# Altium schematic sheet Relay.SchDoc
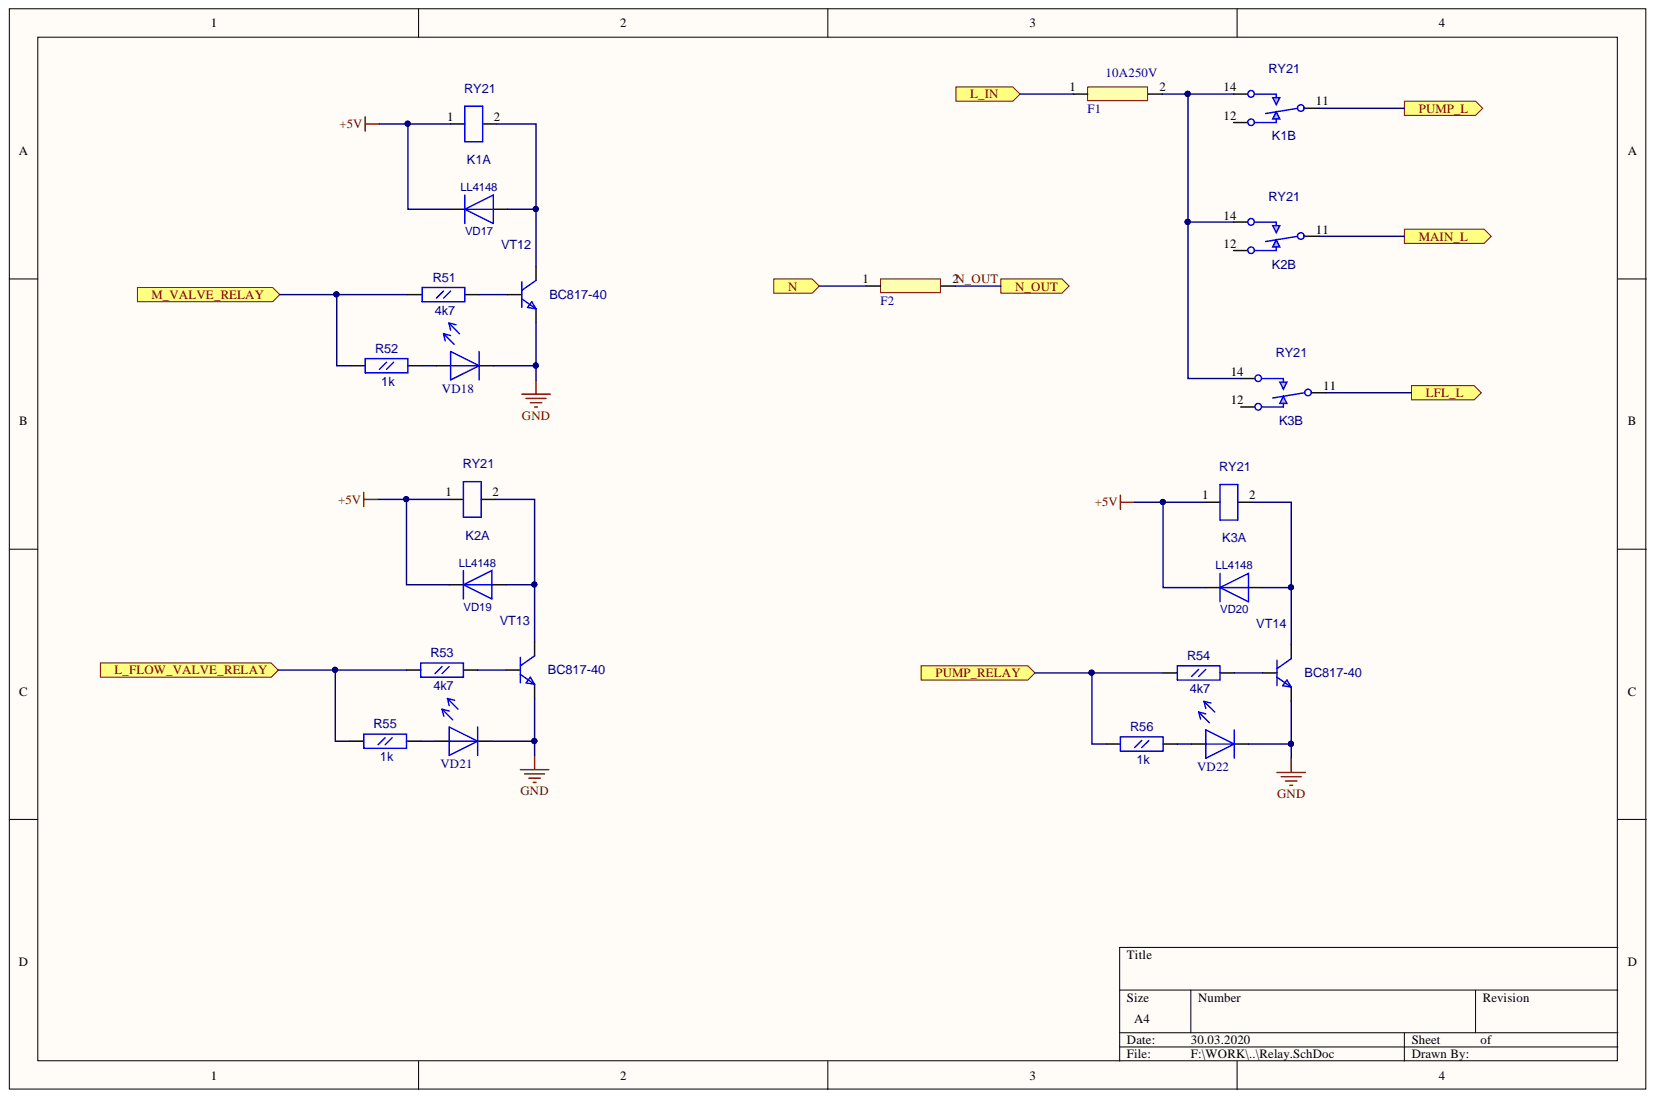
[diagram p0: Relay.SchDoc]

## Parts
F1  KLS5-266(5x20) 2pcs  [Fuse_5x20]  (value not drawn)
F2  KLS5-266(5x20) 2pcs  [Fuse_5x20]  (value not drawn)
K1  RY21  [RY21_RY21(Primary)]  (parts A,B)
K2  RY21  [RY21_RY21(Primary)]  (parts A,B)
K3  RY21  [RY21_RY21(Primary)]  (parts A,B)
R51  4k7  [RC0603]
R52  1k  [RC0603]
R53  4k7  [RC0603]
R54  4k7  [RC0603]
R55  1k  [RC0603]
R56  1k  [RC0603]
VD17  LL4148  [SOD-80]
VD18  LED_0805  [LED0805]  (value not drawn)
VD19  LL4148  [SOD-80]
VD20  LL4148  [SOD-80]
VD21  LED_0805  [LED0805]  (value not drawn)
VD22  LED_0805  [LED0805]  (value not drawn)
VT12  BC817-40  [SOT-23(123)]
VT13  BC817-40  [SOT-23(123)]
VT14  BC817-40  [SOT-23(123)]

## Ports
L_FLOW_VALVE_RELAY, L_IN, LFL_L, M_VALVE_RELAY, MAIN_L, N, N_OUT, PUMP_L, PUMP_RELAY

## Nets
+5V: K1.1 K2.1 K3.1 VD17.2 VD19.2 VD20.2
GND: VD18.2 VD21.2 VD22.2 VT12.2 VT13.2 VT14.2
L_FLOW_VALVE_RELAY: R53.1 R55.1 ; port L_FLOW_VALVE_RELAY
L_IN: F1.1 ; port L_IN
LFL_L: K3.11 ; port LFL_L
M_VALVE_RELAY: R51.1 R52.1 ; port M_VALVE_RELAY
MAIN_L: K2.11 ; port MAIN_L
N: F2.1 ; port N
N_OUT: F2.2 ; port N_OUT
PUMP_L: K1.11 ; port PUMP_L
PUMP_RELAY: R54.1 R56.1 ; port PUMP_RELAY
NetF1_2: F1.2 K1.14 K2.14 K3.14
NetK1_2: K1.2 VD17.1 VT12.3
NetK2_2: K2.2 VD19.1 VT13.3
NetK3_2: K3.2 VD20.1 VT14.3
NetR51_2: R51.2 VT12.1
NetR52_2: R52.2 VD18.1
NetR53_2: R53.2 VT13.1
NetR54_2: R54.2 VT14.1
NetR55_2: R55.2 VD21.1
NetR56_2: R56.2 VD22.1

Not connected: K1 12; K2 12; K3 12

## Library data of the parts
F1 F2 = Fuse KLS5-266(5x20): Component Kind: Standard; Value: KLS5-266(5x20) 2pcs; Library Name: gprs_modem_2.SchLib
R51-R56 = RC0603: Component Kind: Standard; Description: RC0603; Library Name: gprs_modem_2.SchLib
  R51 R53 R54: Value: 4k7
  R52 R55 R56: Value: 1k
VT12-VT14 = BC846BLT1: NPN 500ma transistor; ComponentField7: Published 29-Sep-2000; Component Kind: Standard; OriginalName: BC846BLT1; Simulation: BC846BLT1; Value: BC817-40; Library Name: gprs_modem_2.SchLib
K1-K3 = RY21: Component Kind: Standard; Description: RY21; Library Name: shlagbaum.SchLib
VD17 VD19 VD20 = LL4148: Component Kind: Standard; Description: LL4148; Library Name: gprs_modem_2.SchLib
VD18 VD21 VD22 = LED_0805: Component Kind: Standard; Value: LED_0805; Library Name: gprs_modem_2.SchLib
